# Altium schematic sheet Sheet1.SchDoc(Sheet1)
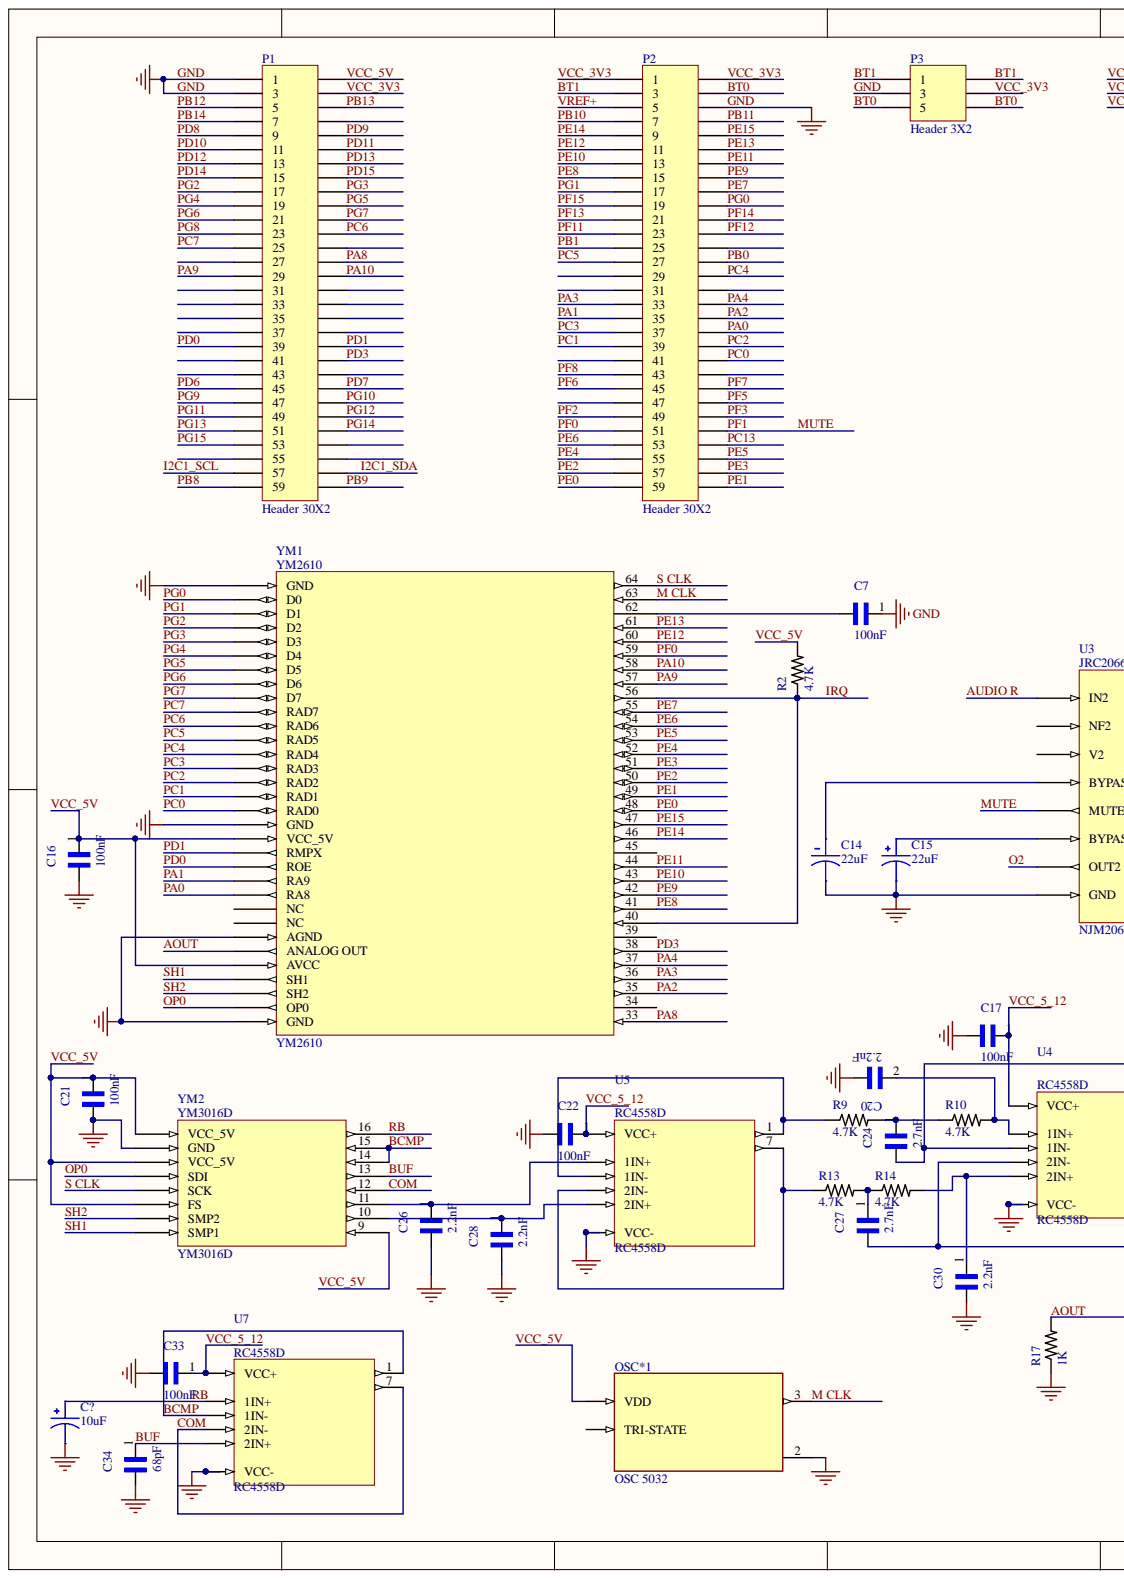
[diagram p0: Sheet1.SchDoc, left]
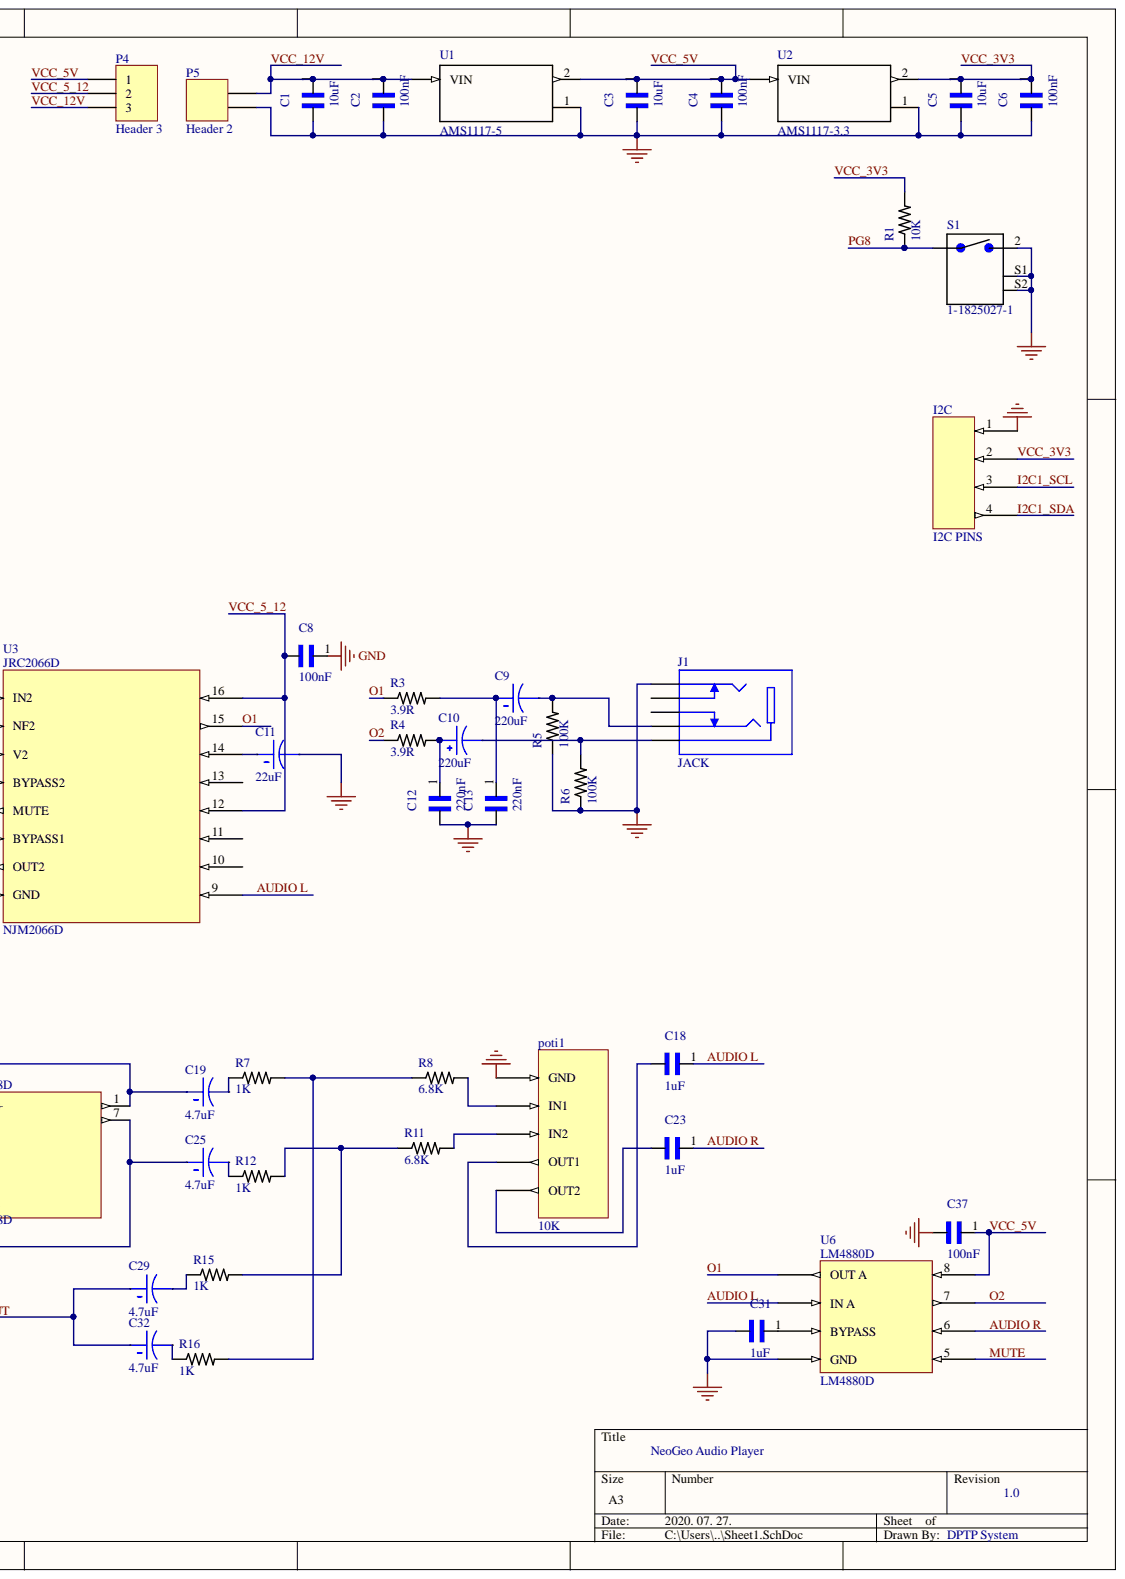
[diagram p1: Sheet1.SchDoc, right]

## Parts
C1  10uF  [CAP0603]
C2  100nF  [CAP0603]
C3  10uF  [CAP0603]
C4  100nF  [CAP0603]
C5  10uF  [CAP0603]
C6  100nF  [CAP0603]
C7  100nF  [CAP0603]
C8  100nF  [CAP0603]
C9  220uF  [ELEKTOLIT CAP 8x8]
C10  220uF  [ELEKTOLIT CAP 8x8]
C11  22uF  [ELEKTOLIT CAP 8x8]
C12  220nF  [CAP0603]
C13  220nF  [CAP0603]
C14  22uF  [ELEKTOLIT CAP 8x8]
C15  22uF  [ELEKTOLIT CAP 8x8]
C16  100nF  [CAP0603]
C17  100nF  [CAP0603]
C18  1uF  [CAP0603]
C19  4.7uF  [ELEKTOLIT CAP 8x8]
C20  2.2nF  [CAP0603]
C21  100nF  [CAP0603]
C22  100nF  [CAP0603]
C23  1uF  [CAP0603]
C24  2.7nF  [CAP0603]
C25  4.7uF  [ELEKTOLIT CAP 8x8]
C26  2.2nF  [CAP0603]
C27  2.7nF  [CAP0603]
C28  2.2nF  [CAP0603]
C29  4.7uF  [ELEKTOLIT CAP 8x8]
C30  2.2nF  [CAP0603]
C31  1uF  [CAP0603]
C32  4.7uF  [ELEKTOLIT CAP 8x8]
C33  100nF  [CAP0603]
C34  68pF  [CAP0603]
C37  100nF  [CAP0603]
C?  10uF  [ELEKTOLIT CAP 8x8]
I2C  I2C PINS  [I2C PINS]
J1  JACK  [JACK]
OSC*1  OSC 5032  [OSC 5032]
P1  Header 30X2  [HDR2X30]
P2  Header 30X2  [HDR2X30]
P3  Header 3X2  [HDR2X3]
P4  Header 3  [HDR1X3]
P5  Header 2  [HDR1X2]
poti1  10K  [10K POT]
R1  10K  [RES0603]
R2  4.7K  [RES0603]
R3  3.9R  [RES0603]
R4  3.9R  [RES0603]
R5  100K  [RES0603]
R6  100K  [RES0603]
R7  1K  [RES0603]
R8  6.8K  [RES0603]
R9  4.7K  [RES0603]
R10  4.7K  [RES0603]
R11  6.8K  [RES0603]
R12  1K  [RES0603]
R13  4.7K  [RES0603]
R14  4.7K  [RES0603]
R15  1K  [RES0603]
R16  1K  [RES0603]
R17  1K  [RES0603]
S1  1-1825027-1  [SW_1-1825027-1_1-1825027-1(Primary)]
U1  AMS1117-5  [SOT229P700X180-4N]
U2  AMS1117-3.3  [SOT229P700X180-4N]
U3  NJM2066D  [JRC2066D]
U4  RC4558D  [JRC4558D]
U5  RC4558D  [JRC4558D]
U6  LM4880D  [LM4880D]
U7  RC4558D  [JRC4558D]
YM1  YM2610  [YM2610]
YM2  YM3016D  [SN76489AN]

## Nets
AOUT: C29.1 C32.1 R17.2 YM1.27(ANALOG_OUT)
AUDIO L: C18.1 U3.9 U6.2(IN_A)
AUDIO R: C23.1 U3.1(IN2) U6.6
BCMP: U7.1 U7.2(1IN-) YM2.14 YM2.15
BT0: P2.4 P3.5 P3.6
BT1: P2.3 P3.1 P3.2
BUF: C34.1 U7.5(2IN+) YM2.13
COM: U7.6(2IN-) U7.7 YM2.12
GND: C1.2 C2.2 C3.2 C4.2 C5.2 C6.2 C7.1 C8.1 C11.2 C12.2 C13.2 C14.2 C15.2 C16.2 C17.2 C20.1 C21.2 C22.2 C26.2 C28.2 C30.2 C31.2 C33.2 C34.2 C37.2 C?.2 I2C.1 J1.2 OSC*1.2 P1.1 P1.3 P2.6 P3.3 P5.1 poti1.1(GND) R5.1 R6.1 R17.1 S1.2 S1.S1 S1.S2 U1.1 U2.1 U3.8(GND) U4.4(VCC-) U5.4(VCC-) U6.4(GND) U7.4(VCC-) YM1.1(GND) YM1.18(GND) YM1.26(AGND) YM1.32(GND) YM2.2(GND)
I2C1_SCL: I2C.3 P1.57
I2C1_SDA: I2C.4 P1.58
IRQ: R2.1 YM1.40 YM1.56
M CLK: OSC*1.3 YM1.63
MUTE: P2.52 U3.5(MUTE) U6.5
O1: R3.1 U3.15 U6.1(OUT_A)
O2: R4.1 U3.7(OUT2) U6.7
OP0: YM1.31(OP0) YM2.4(SDI)
PA0: P2.38 YM1.23(RA8)
PA1: P2.35 YM1.22(RA9)
PA2: P2.36 YM1.35
PA3: P2.33 YM1.36
PA4: P2.34 YM1.37
PA8: P1.28 YM1.33
PA9: P1.29 YM1.57
PA10: P1.30 YM1.58
PB0: P2.28
PB1: P2.25
PB8: P1.59
PB9: P1.60
PB10: P2.7
PB11: P2.8
PB12: P1.5
PB13: P1.6
PB14: P1.7
PC0: P2.42 YM1.17(RAD0)
PC1: P2.39 YM1.16(RAD1)
PC2: P2.40 YM1.15(RAD2)
PC3: P2.37 YM1.14(RAD3)
PC4: P2.30 YM1.13(RAD4)
PC5: P2.27 YM1.12(RAD5)
PC6: P1.24 YM1.11(RAD6)
PC7: P1.25 YM1.10(RAD7)
PC13: P2.54
PD0: P1.39 YM1.21(ROE)
PD1: P1.40 YM1.20(RMPX)
PD3: P1.42 YM1.38
PD6: P1.45
PD7: P1.46
PD8: P1.9
PD9: P1.10
PD10: P1.11
PD11: P1.12
PD12: P1.13
PD13: P1.14
PD14: P1.15
PD15: P1.16
PE0: P2.59 YM1.48
PE1: P2.60 YM1.49
PE2: P2.57 YM1.50
PE3: P2.58 YM1.51
PE4: P2.55 YM1.52
PE5: P2.56 YM1.53
PE6: P2.53 YM1.54
PE7: P2.18 YM1.55
PE8: P2.15 YM1.41
PE9: P2.16 YM1.42
PE10: P2.13 YM1.43
PE11: P2.14 YM1.44
PE12: P2.11 YM1.60
PE13: P2.12 YM1.61
PE14: P2.9 YM1.46
PE15: P2.10 YM1.47
PF0: P2.51 YM1.59
PF2: P2.49
PF3: P2.50
PF5: P2.48
PF6: P2.45
PF7: P2.46
PF8: P2.43
PF11: P2.23
PF12: P2.24
PF13: P2.21
PF14: P2.22
PF15: P2.19
PG0: P2.20 YM1.2(D0)
PG1: P2.17 YM1.3(D1)
PG2: P1.17 YM1.4(D2)
PG3: P1.18 YM1.5(D3)
PG4: P1.19 YM1.6(D4)
PG5: P1.20 YM1.7(D5)
PG6: P1.21 YM1.8(D6)
PG7: P1.22 YM1.9(D7)
PG8: P1.23 R1.1 S1.1
PG9: P1.47
PG10: P1.48
PG11: P1.49
PG12: P1.50
PG13: P1.51
PG14: P1.52
PG15: P1.53
RB: C?.1 U7.3(1IN+) YM2.16
S CLK: YM1.64 YM2.5(SCK)
SH1: YM1.29(SH1) YM2.8(SMP1)
SH2: YM1.30(SH2) YM2.7(SMP2)
VCC_3V3: C5.1 C6.1 I2C.2 P1.4 P2.1 P2.2 P3.4 R1.2 U2.2
VCC_5_12: C8.2 C17.1 C22.1 C33.1 P4.2 U3.12 U3.16 U4.8(VCC+) U5.8(VCC+) U7.8(VCC+)
VCC_5V: C3.1 C4.1 C16.1 C21.1 C37.1 OSC*1.4(VDD) P1.2 P4.1 R2.2 U1.2 U2.3 U6.8 YM1.19(VCC_5V) YM1.28(AVCC) YM2.1(VCC_5V) YM2.3(VCC_5V) YM2.6(FS) YM2.9
VCC_12V: C1.1 C2.1 P4.3 P5.2 U1.3
VREF+: P2.5
NetC7_2: C7.2 YM1.62
NetC9_1: C9.1 C13.1 R3.2
NetC9_2: C9.2 J1.3 R5.2
NetC10_1: C10.1 C12.1 R4.2
NetC10_2: C10.2 J1.1 R6.2
NetC11_1: C11.1 U3.14
NetC14_1: C14.1 U3.4(BYPASS2)
NetC15_1: C15.1 U3.6(BYPASS1)
NetC18_2: C18.2 poti1.4(OUT1)
NetC19_1: C19.1 C24.2 U4.1 U4.2(1IN-)
NetC19_2: C19.2 R7.1
NetC20_2: C20.2 R10.2 U4.3(1IN+)
NetC23_2: C23.2 poti1.5(OUT2)
NetC24_1: C24.1 R9.2 R10.1
NetC25_1: C25.1 C27.2 U4.6(2IN-) U4.7
NetC25_2: C25.2 R12.1
NetC26_1: C26.1 U5.3(1IN+) YM2.11
NetC27_1: C27.1 R13.2 R14.1
NetC28_1: C28.1 U5.5(2IN+) YM2.10
NetC29_2: C29.2 R15.1
NetC30_1: C30.1 R14.2 U4.5(2IN+)
NetC31_1: C31.1 U6.3(BYPASS)
NetC32_2: C32.2 R16.1
Netpoti1_2: poti1.2(IN1) R8.2
Netpoti1_3: poti1.3(IN2) R11.2
NetR7_2: R7.2 R8.1 R16.2
NetR9_1: R9.1 U5.1 U5.2(1IN-)
NetR11_1: R11.1 R12.2 R15.2
NetR13_1: R13.1 U5.6(2IN-) U5.7

Not connected: J1 4 5; OSC*1 1(TRI-STATE); P1 8 26 27 31 32 33 34 35 36 37 38 41 43 44 54 55 56; P2 26 29 31 32 41 44 47; U3 2(NF2) 3(V2) 10 11 13; YM1 24(NC) 25(NC) 34 39 45

## Library data of the parts
C1-C8 C12 C13 C16-C18 C20-C24 C26-C28 C30 C31 C33 C34 C37 = 0603: Cap Ceramic; MP: 06031A6R0BAT4A; MF: AVX; SnapEDA_Link: https://www.snapeda.com/parts/06031A6R0BAT4A/AVX/view-part/?ref=snap; Price: None; Package: 0603 AVX; Component Kind: Standard; Availability: Unavailable; Library Name: Peti.SchLib
C9 C10 = CAP ELEKTOLIT SMD: SMD CAP; Library Name: stm32f103fr6t.SCHLIB; Component Kind: Standard
C11 C14 C15 C19 C25 C29 C32 C? = CAP ELEKTOLIT SMD: Library Name: stm32f103fr6t.SCHLIB; Component Kind: Standard
P1 P2 = Header 30X2: Header, 30-Pin, Dual row; Signal Integrity: Connector; Library Name: Miscellaneous Connectors.IntLib; LatestRevisionNote: Re-released for DXP Platform.; LatestRevisionDate: 17-Jul-2002; Component Kind: Standard; Publisher: Altium Limited
P3 = Header 3X2: Header, 3-Pin, Dual row; Signal Integrity: Connector; Library Name: Miscellaneous Connectors.IntLib; LatestRevisionNote: Re-released for DXP Platform.; LatestRevisionDate: 17-Jul-2002; Component Kind: Standard; Publisher: Altium Limited
P4 = Header 3: Header, 3-Pin; Signal Integrity: Connector; Library Name: Miscellaneous Connectors.IntLib; LatestRevisionNote: Re-released for DXP Platform.; LatestRevisionDate: 17-Jul-2002; Component Kind: Standard; Publisher: Altium Limited
P5 = Header 2: Header, 2-Pin; Signal Integrity: Connector; Library Name: Miscellaneous Connectors.IntLib; LatestRevisionNote: Re-released for DXP Platform.; LatestRevisionDate: 17-Jul-2002; Component Kind: Standard; Publisher: Altium Limited
poti1 = POTI 10K TEKEROS: 10K potentiometer; Library Name: stm32f103fr6t.SCHLIB; Component Kind: Standard
R1-R17 = 0603RES: MP: EXB-34V103JV; MF: Panasonic; SnapEDA_Link: https://www.snapeda.com/parts/EXB-34V103JV/Panasonic%20Electronic%20Components/view-part/?ref=snap; Price: None; Package: 0606 Panasonic; Description: 0603RES; Component Kind: Standard; Availability: Unavailable; Library Name: Peti.SchLib
S1 = 1-1825027-1: Switch Push Button OFF _ON_ SPST Round Button 0.05A 24VDC Momentary Contact PC Pins Thru-Hole; MF: TE Connectivity; SnapEDA_Link: https://www.snapeda.com/parts/1-1825027-1/TE%20Connectivity%20ALCOSWITCH%20Switches/view-part/?ref=snap; Price: None; Package: None; MP: 1-1825027-1; Link: http://www.te.com/usa-en/product-1-1825027-1.html; EU_RoHS_Compliance: Compliant; Component Kind: Standard; Availability: Unavailable; Library Name: Peti.SchLib
U1 = AMS1117-3.3: Sot223/Pkg 1-Amp 5-Volt Low Drop Out Voltage Regulatator; MF: Advanced Monolithic Systems; SnapEDA_Link: https://www.snapeda.com/parts/AMS1117-3.3/Advanced%20Monolithic%20Systems/view-part/?ref=snap; Price: None; Package: None; MP: AMS1117-3.3; Component Kind: Standard; Availability: Unavailable; Library Name: Peti.SchLib
U2 = AMS1117-3.3: Sot223/Pkg 1-Amp 3.3-Volt Low Drop Out Voltage Regulatator; MF: Advanced Monolithic Systems; SnapEDA_Link: https://www.snapeda.com/parts/AMS1117-3.3/Advanced%20Monolithic%20Systems/view-part/?ref=snap; Price: None; Package: None; MP: AMS1117-3.3; Component Kind: Standard; Availability: Unavailable; Library Name: Peti.SchLib
U3 = NJM2066D: JRC2066D; Library Name: stm32f103fr6t.SCHLIB; Component Kind: Standard
YM1 = YM2610: YM1610; Library Name: stm32f103fr6t.SCHLIB; Component Kind: Standard
I2C = I2C PINS: Component Kind: Standard; Library Name: Peti.SchLib
J1 = JACK: Library Name: stm32f103fr6t.SCHLIB; Component Kind: Standard
OSC*1 = OSC 5032: Library Name: stm32f103fr6t.SCHLIB; Description: OSC 5032; Component Kind: Standard
U4 U5 U7 = RC4558D: SnapEDA_Link: https://www.snapeda.com/parts/RC4558D/Texas%20Instruments/view-part/493512/?ref=snap; Library Name: stm32f103fr6t.SCHLIB; Description: RC4558D; Component Kind: Standard
U6 = LM4880D: Library Name: stm32f103fr6t.SCHLIB; Description: LM4880D; Component Kind: Standard
YM2 = YM3016D: Library Name: stm32f103fr6t.SCHLIB; Description: YM3016D; Component Kind: Standard
